# Altium schematic sheet CN032-1 Industry EtherCAT Servo Controller_EtherCAT.SchDoc
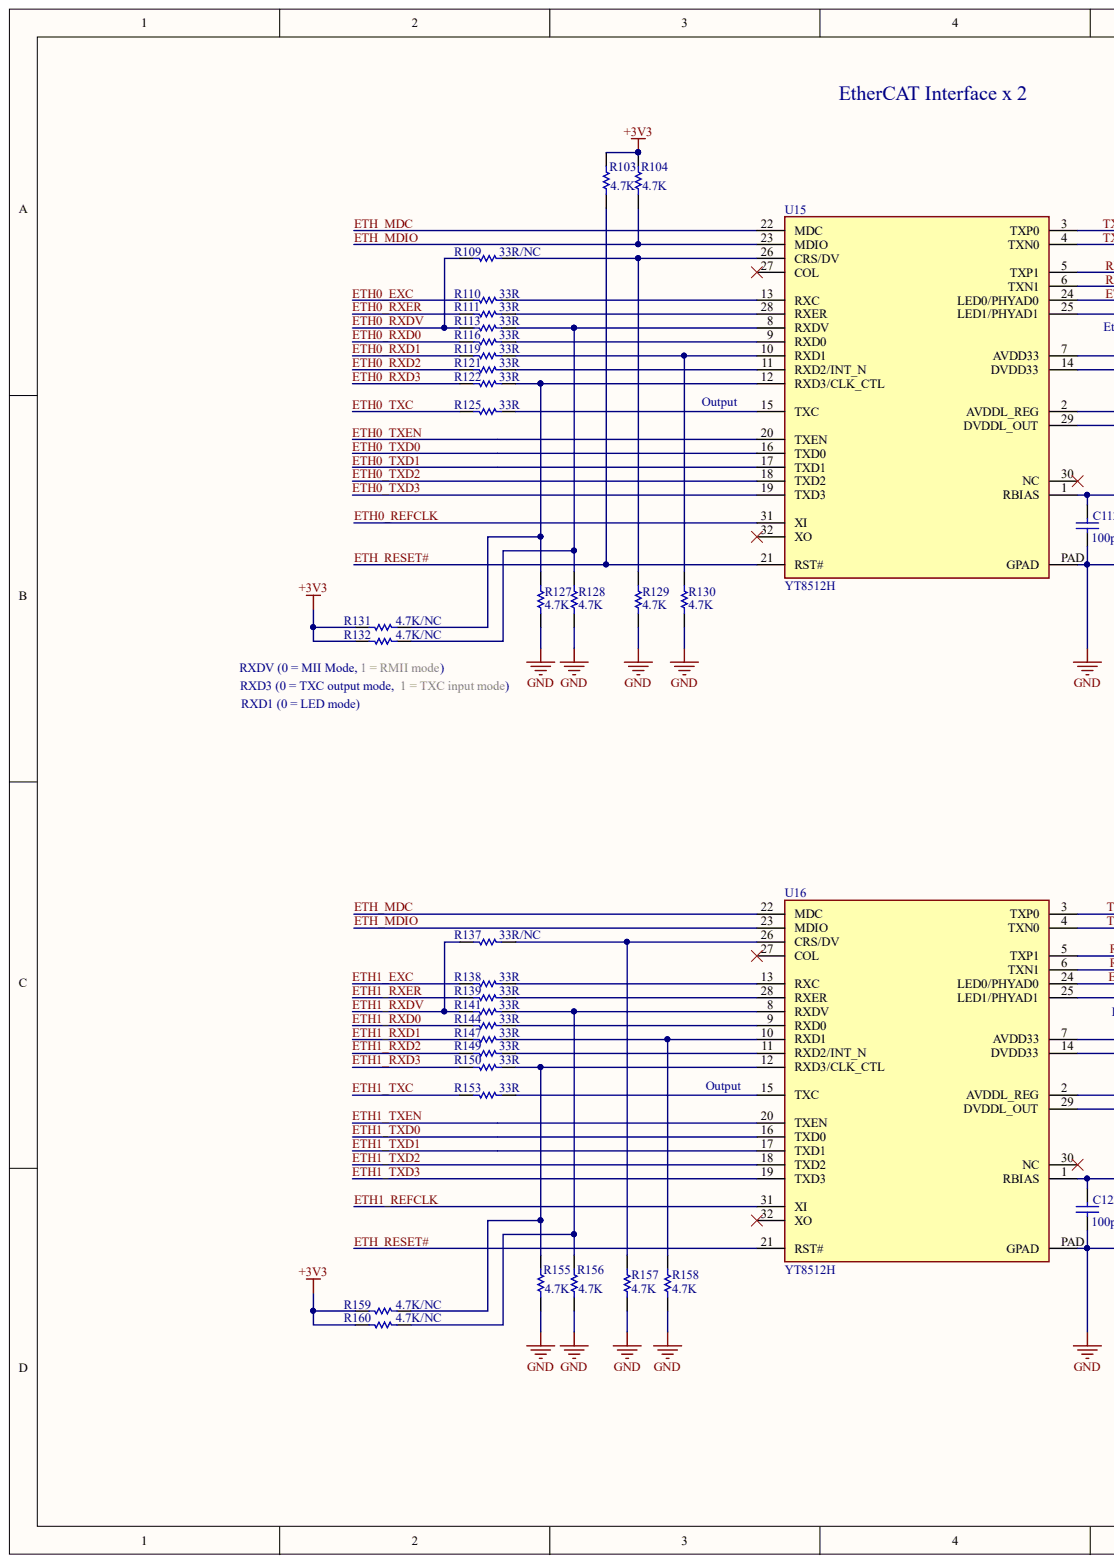
[diagram p0: CN032-1 Industry EtherCAT Servo Controller_EtherCAT.SchDoc, left]
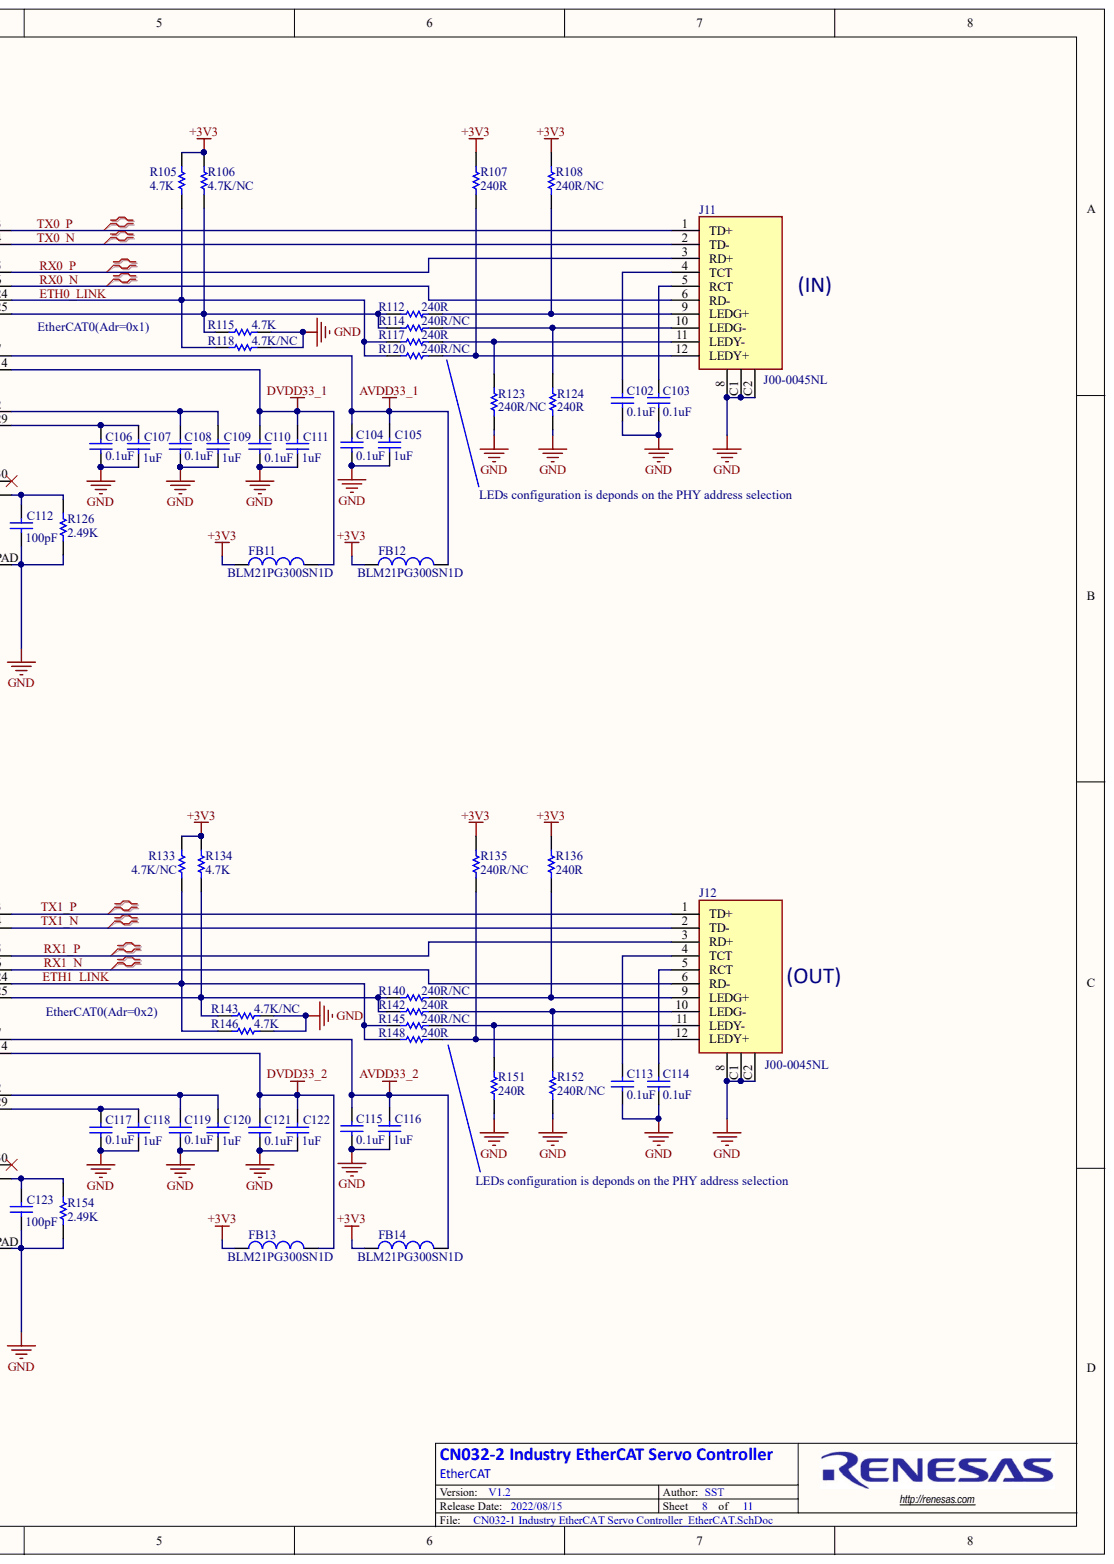
[diagram p1: CN032-1 Industry EtherCAT Servo Controller_EtherCAT.SchDoc, right]

## Parts
C102  0.1uF  [CAPC0402-01]
C103  0.1uF  [CAPC0402-01]
C104  0.1uF  [CAPC0402-01]
C105  1uF  [CAPC0402-01]
C106  0.1uF  [CAPC0402-01]
C107  1uF  [CAPC0402-01]
C108  0.1uF  [CAPC0402-01]
C109  1uF  [CAPC0402-01]
C110  0.1uF  [CAPC0402-01]
C111  1uF  [CAPC0402-01]
C112  100pF  [CAPC0402-01]
C113  0.1uF  [CAPC0402-01]
C114  0.1uF  [CAPC0402-01]
C115  0.1uF  [CAPC0402-01]
C116  1uF  [CAPC0402-01]
C117  0.1uF  [CAPC0402-01]
C118  1uF  [CAPC0402-01]
C119  0.1uF  [CAPC0402-01]
C120  1uF  [CAPC0402-01]
C121  0.1uF  [CAPC0402-01]
C122  1uF  [CAPC0402-01]
C123  100pF  [CAPC0402-01]
FB11  BLM21PG300SN1D  [FB_0805]
FB12  BLM21PG300SN1D  [FB_0805]
FB13  BLM21PG300SN1D  [FB_0805]
FB14  BLM21PG300SN1D  [FB_0805]
J11  J00-0045NL  [ABRACON_ARJC02]
J12  J00-0045NL  [ABRACON_ARJC02]
R103  4.7K  [RESC0402-01]
R104  4.7K  [RESC0402-01]
R105  4.7K  [RESC0402-01]
R106  4.7K/NC  [RESC0402-01]
R107  240R  [RESC0402-01]
R108  240R/NC  [RESC0402-01]
R109  33R/NC  [RESC0402-01]
R110  33R  [RESC0402-01]
R111  33R  [RESC0402-01]
R112  240R  [RESC0402-01]
R113  33R  [RESC0402-01]
R114  240R/NC  [RESC0402-01]
R115  4.7K  [RESC0402-01]
R116  33R  [RESC0402-01]
R117  240R  [RESC0402-01]
R118  4.7K/NC  [RESC0402-01]
R119  33R  [RESC0402-01]
R120  240R/NC  [RESC0402-01]
R121  33R  [RESC0402-01]
R122  33R  [RESC0402-01]
R123  240R/NC  [RESC0402-01]
R124  240R  [RESC0402-01]
R125  33R  [RESC0402-01]
R126  2.49K  [RESC0402-01]
R127  4.7K  [RESC0402-01]
R128  4.7K  [RESC0402-01]
R129  4.7K  [RESC0402-01]
R130  4.7K  [RESC0402-01]
R131  4.7K/NC  [RESC0402-01]
R132  4.7K/NC  [RESC0402-01]
R133  4.7K/NC  [RESC0402-01]
R134  4.7K  [RESC0402-01]
R135  240R/NC  [RESC0402-01]
R136  240R  [RESC0402-01]
R137  33R/NC  [RESC0402-01]
R138  33R  [RESC0402-01]
R139  33R  [RESC0402-01]
R140  240R/NC  [RESC0402-01]
R141  33R  [RESC0402-01]
R142  240R  [RESC0402-01]
R143  4.7K/NC  [RESC0402-01]
R144  33R  [RESC0402-01]
R145  240R/NC  [RESC0402-01]
R146  4.7K  [RESC0402-01]
R147  33R  [RESC0402-01]
R148  240R  [RESC0402-01]
R149  33R  [RESC0402-01]
R150  33R  [RESC0402-01]
R151  240R  [RESC0402-01]
R152  240R/NC  [RESC0402-01]
R153  33R  [RESC0402-01]
R154  2.49K  [RESC0402-01]
R155  4.7K  [RESC0402-01]
R156  4.7K  [RESC0402-01]
R157  4.7K  [RESC0402-01]
R158  4.7K  [RESC0402-01]
R159  4.7K/NC  [RESC0402-01]
R160  4.7K/NC  [RESC0402-01]
U15  YT8512H  [QFN-32 (5x5)]
U16  YT8512H  [QFN-32 (5x5)]

## Nets
+3V3: FB11.1 FB12.1 FB13.1 FB14.1 R103.2 R104.2 R105.1 R106.1 R107.2 R108.2 R131.2 R132.2 R133.1 R134.1 R135.2 R136.2 R159.2 R160.2
AVDD33_1: C104.2 C105.2 FB12.2 U15.7(AVDD33)
AVDD33_2: C115.2 C116.2 FB14.2 U16.7(AVDD33)
DVDD33_1: C110.2 C111.2 FB11.2 U15.14(DVDD33)
DVDD33_2: C121.2 C122.2 FB13.2 U16.14(DVDD33)
GND: C102.1 C103.1 C104.1 C105.1 C106.1 C107.1 C108.1 C109.1 C110.1 C111.1 C112.1 C113.1 C114.1 C115.1 C116.1 C117.1 C118.1 C119.1 C120.1 C121.1 C122.1 C123.1 J11.8 J11.C1 J11.C2 J12.8 J12.C1 J12.C2 R115.1 R118.1 R123.1 R124.1 R126.1 R127.1 R128.1 R129.2 R130.2 R143.1 R146.1 R151.1 R152.1 R154.1 R155.1 R156.1 R157.2 R158.2 U15.PAD(GPAD) U16.PAD(GPAD)
ETH0_EXC: R110.2
ETH0_LINK: R105.2 R117.1 R118.2 R120.1 U15.24(LED0/PHYAD0)
ETH0_REFCLK: U15.31(XI)
ETH0_RXD0: R116.2
ETH0_RXD1: R119.2
ETH0_RXD2: R121.2
ETH0_RXD3: R122.2
ETH0_RXDV: R109.2 R113.2
ETH0_RXER: R111.2
ETH0_TXC: R125.2
ETH0_TXD0: U15.16(TXD0)
ETH0_TXD1: U15.17(TXD1)
ETH0_TXD2: U15.18(TXD2)
ETH0_TXD3: U15.19(TXD3)
ETH0_TXEN: U15.20(TXEN)
ETH1_EXC: R138.2
ETH1_LINK: R133.2 R145.1 R146.2 R148.1 U16.24(LED0/PHYAD0)
ETH1_REFCLK: U16.31(XI)
ETH1_RXD0: R144.2
ETH1_RXD1: R147.2
ETH1_RXD2: R149.2
ETH1_RXD3: R150.2
ETH1_RXDV: R137.2 R141.2
ETH1_RXER: R139.2
ETH1_TXC: R153.2
ETH1_TXD0: U16.16(TXD0)
ETH1_TXD1: U16.17(TXD1)
ETH1_TXD2: U16.18(TXD2)
ETH1_TXD3: U16.19(TXD3)
ETH1_TXEN: U16.20(TXEN)
ETH_MDC: U15.22(MDC) U16.22(MDC)
ETH_MDIO: R104.1 U15.23(MDIO) U16.23(MDIO)
ETH_RESET#: R103.1 U15.21(RST#) U16.21(RST#)
RX0_N: J11.6(RD-) U15.6(TXN1)
RX0_P: J11.3(RD+) U15.5(TXP1)
RX1_N: J12.6(RD-) U16.6(TXN1)
RX1_P: J12.3(RD+) U16.5(TXP1)
TX0_N: J11.2(TD-) U15.4(TXN0)
TX0_P: J11.1(TD+) U15.3(TXP0)
TX1_N: J12.2(TD-) U16.4(TXN0)
TX1_P: J12.1(TD+) U16.3(TXP0)
NetC102_2: C102.2 J11.4(TCT)
NetC103_2: C103.2 J11.5(RCT)
NetC106_2: C106.2 C107.2 U15.29(DVDDL_OUT)
NetC108_2: C108.2 C109.2 U15.2(AVDDL_REG)
NetC112_2: C112.2 R126.2 U15.1(RBIAS)
NetC113_2: C113.2 J12.4(TCT)
NetC114_2: C114.2 J12.5(RCT)
NetC117_2: C117.2 C118.2 U16.29(DVDDL_OUT)
NetC119_2: C119.2 C120.2 U16.2(AVDDL_REG)
NetC123_2: C123.2 R154.2 U16.1(RBIAS)
NetJ11_9: J11.9(LEDG+) R108.1 R112.2
NetJ11_10: J11.10(LEDG-) R114.2 R124.2
NetJ11_11: J11.11(LEDY-) R117.2 R123.2
NetJ11_12: J11.12(LEDY+) R107.1 R120.2
NetJ12_9: J12.9(LEDG+) R136.1 R140.2
NetJ12_10: J12.10(LEDG-) R142.2 R152.2
NetJ12_11: J12.11(LEDY-) R145.2 R151.2
NetJ12_12: J12.12(LEDY+) R135.1 R148.2
NetR106_2: R106.2 R112.1 R114.1 R115.2 U15.25(LED1/PHYAD1)
NetR109_1: R109.1 R129.1 U15.26(CRS/DV)
NetR110_1: R110.1 U15.13(RXC)
NetR111_1: R111.1 U15.28(RXER)
NetR113_1: R113.1 R128.2 R132.1 U15.8(RXDV)
NetR116_1: R116.1 U15.9(RXD0)
NetR119_1: R119.1 R130.1 U15.10(RXD1)
NetR121_1: R121.1 U15.11(RXD2/INT_N)
NetR122_1: R122.1 R127.2 R131.1 U15.12(RXD3/CLK_CTL)
NetR125_1: R125.1 U15.15(TXC)
NetR134_2: R134.2 R140.1 R142.1 R143.2 U16.25(LED1/PHYAD1)
NetR137_1: R137.1 R157.1 U16.26(CRS/DV)
NetR138_1: R138.1 U16.13(RXC)
NetR139_1: R139.1 U16.28(RXER)
NetR141_1: R141.1 R156.2 R160.1 U16.8(RXDV)
NetR144_1: R144.1 U16.9(RXD0)
NetR147_1: R147.1 R158.1 U16.10(RXD1)
NetR149_1: R149.1 U16.11(RXD2/INT_N)
NetR150_1: R150.1 R155.2 R159.1 U16.12(RXD3/CLK_CTL)
NetR153_1: R153.1 U16.15(TXC)

Not connected: U15 27(COL) 30(NC) 32(XO); U16 27(COL) 30(NC) 32(XO)

## Library data of the parts
C102-C104 C106 C108 C110 C113-C115 C117 C119 C121 = CAP-0402-0.1uF-YAGEO: Ceramic Chip Capacitor 0402 0.1uF 16V; Manufacturer: YAGEO; Mfg Part Number: CC0402KPX7R7BB104; Dialectric: X7R; Tolerance: 10%; Capacitance: 0.1uF; Component Kind: Standard; Package: 0402; Voltage: 16V; Library Name: Synergy.SchLib
C105 C107 C109 C111 C116 C118 C120 C122 = CAP-0402-1uF: Ceramic Chip Capacitor 0402 1uF 16V; Manufacturer: YAGEO; Mfg Part Number: CC0402KRX5R7BB105; Dialectric: X5R; Tolerance: 10%; Capacitance: 1uF; Component Kind: Standard; Package: 0402; Voltage: 16V; Library Name: Synergy.IntLib
C112 C123 = CAP-0402-100pF-YAGEO: Ceramic Chip Capacitor 0402 100pF 50V; Manufacturer: YAGEO; Mfg Part Number: CC0402JRNPO9BN101; Dialectric: COG; Tolerance: 5%; Capacitance: 100pF; Component Kind: Standard; Package: 0402; Voltage: 50V; Library Name: Synergy.IntLib
FB11-FB14 = FB-BLM21PG300SN1D: FERRITE BEAD 30 OHM 0805 1LN; Manufacturer: Murata; Current: 4A; Resistance: 14mΩ; Component Kind: Standard; Mfg Part Number: BLM21PG300SN1D; Package: 0805; Library Name: Synergy.SchLib
J11 J12 = CON-RJ45: 10/100BaseTX RJ45 Ethernet MagJack; Manufacturer: Pulse Electronics Network; Component Kind: Standard; Mfg Part Number: J00-0045NL; Library Name: Synergy.IntLib
R103-R106 R115 R118 R127-R134 R143 R146 R155-R160 = RES-0402-4.7K: Chip Resistor Thick Film 0402 4.7K 1% 1/16W; Manufacturer: YAGEO; Mfg Part Number: RC0402FR-074K7L; Component Kind: Standard; Package: 0402; Power: 1/16W; Rating: 1%; Library Name: Synergy.IntLib
  R103-R105 R115 R127-R130 R134 R146 R155-R158: Value: 4.7K
  R106 R118 R131-R133 R143 R159 R160: Value: 4.7K/NC
R107 R108 R112 R114 R117 R120 R123 R124 R135 R136 R140 R142 R145 R148 R151 R152 = RES-0402-240R: Chip Resistor Thick Film 0402 240R 1% 1/16W; Manufacturer: YAGEO; Mfg Part Number: RC0402FR-07240RL; Component Kind: Standard; Package: 0402; Power: 1/16W; Tolerance: 1%; Library Name: Synergy.SchLib
  R107 R112 R117 R124 R136 R142 R148 R151: Resistance: 240R
  R108 R114 R120 R123 R135 R140 R145 R152: Resistance: 240R/NC
R109-R111 R113 R116 R119 R121 R122 R125 R137-R139 R141 R144 R147 R149 R150 R153 = RES-0402-33R: Chip Resistor Thick Film 0402 33R 5% 1/16W; Manufacturer: YAGEO; Mfg Part Number: RC0402JR-0733RL; Component Kind: Standard; Package: 0402; Power: 1/16W; Tolerance: 5%; Library Name: Synergy.SchLib
  R109 R137: Resistance: 33R/NC
  R110 R111 R113 R116 R119 R121 R122 R125 R138 R139 R141 R144 R147 R149 R150 R153: Resistance: 33R
R126 R154 = RES-0402-2.49K: Chip Resistor Thick Film 0402 2.49K 1% 1/16W; Manufacturer: YAGEO; Mfg Part Number: RC0402FR-072K49L; Component Kind: Standard; Package: 0402; Power: 1/16W; Resistance: 2.49K; Tolerance: 1%; Library Name: Synergy.IntLib
U15 U16 = ICN-YT8512H: Single-port 10/100 Mbps Ethernet PHY 32QFN; Manufacturer: Motorcomm; Component Kind: Standard; Display Name: YT8512H; Mfg Part Number: YT8512H; Package: 32-QFN（5x5）; Library Name: Synergy.SchLib
